# Altium schematic sheet Picc.SchDoc(Picc)
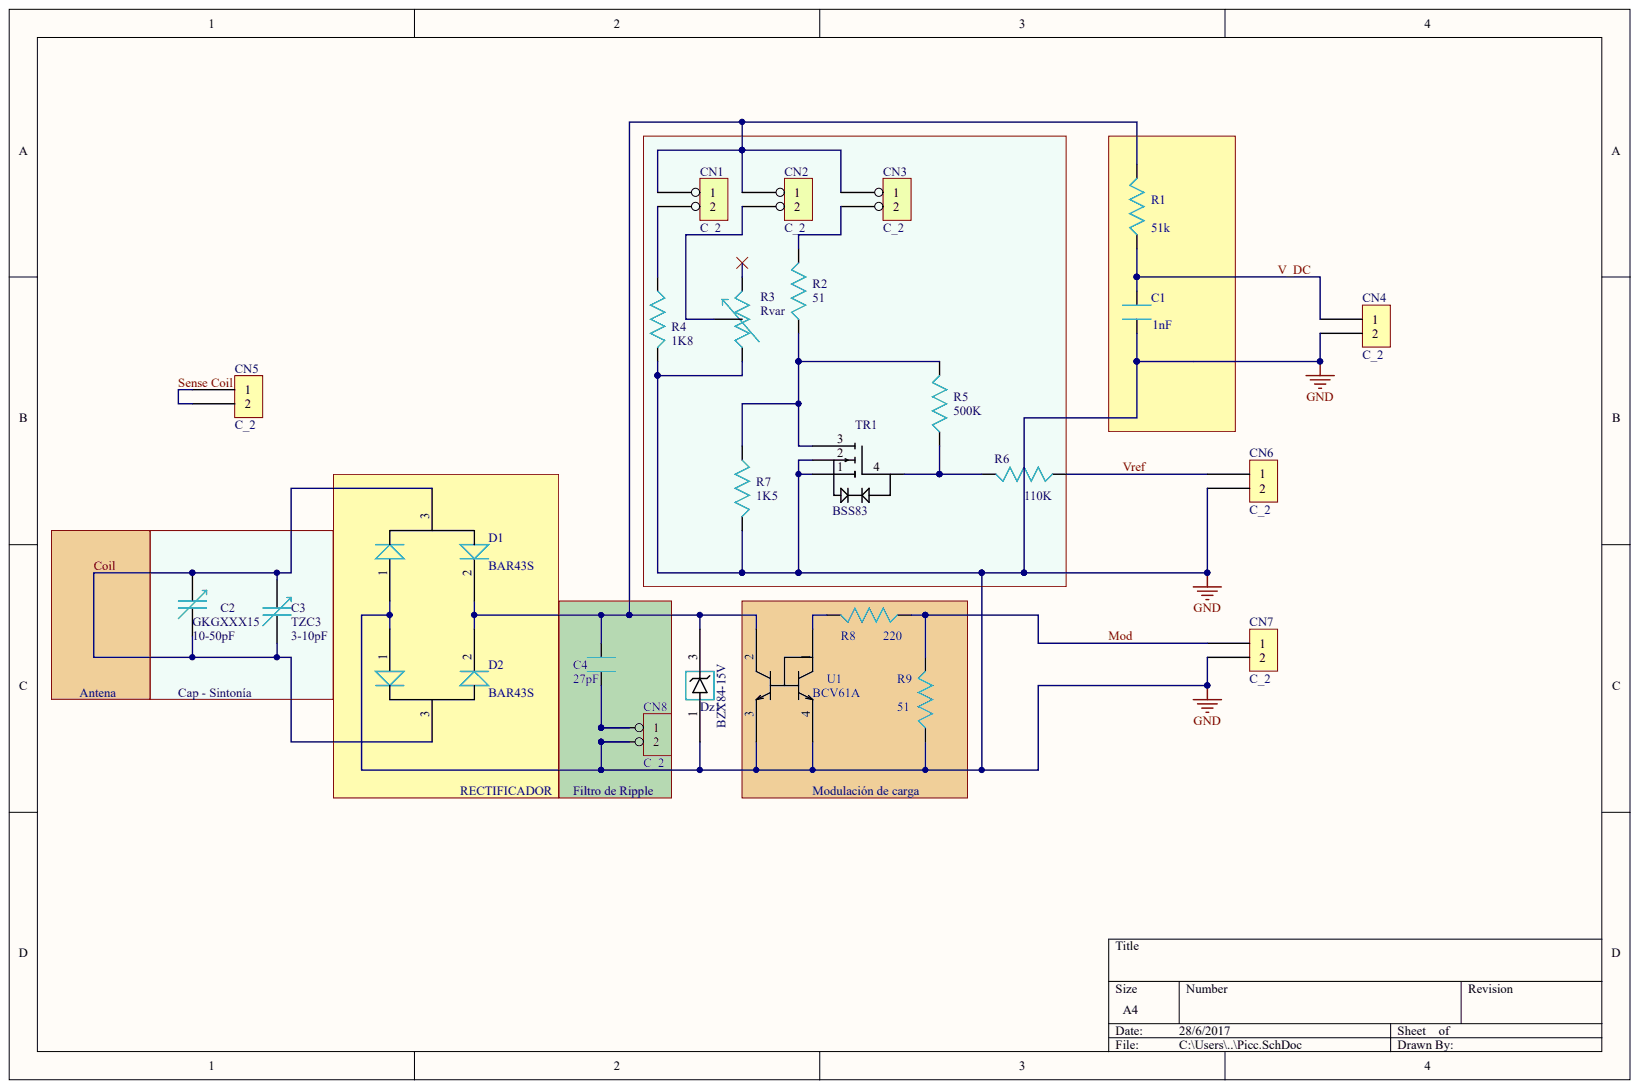
[diagram p0: Picc.SchDoc]

## Parts
C1  1nF
C2  GKGXXX15
C3  TZC3
C4  27pF
CN1  C_2
CN2  C_2
CN3  C_2
CN4  C_2
CN5  C_2
CN6  C_2
CN7  C_2
CN8  C_2
D1  BAR43S
D2  BAR43S
Dz1  BZX84-15V
R1  51k
R2  51
R3  Rvar
R4  1K8
R5  500K
R6  110K
R7  1K5
R8  220
R9  51
TR1  BSS83
U1  BCV61A

## Nets
GND: C1.1 CN4.2 CN6.2 CN7.2 CN8.2 D1.1 D2.1 Dz1.1 R3.1 R4.2 R7.2 R9.1 TR1.1 TR1.2 U1.3 U1.4
COIL: C2.0 C2.1 C3.0 C3.1 D1.3 D2.3
MOD: CN7.1 R8.1 R9.2
SENSE COIL: CN5.1 CN5.2
V_DC: C1.2 CN4.1 R1.1
VREF: CN6.1 R6.1
NetC4_1: C4.1 CN8.1
NetC4_2: C4.2 CN1.1 CN2.1 CN3.1 D1.2 D2.2 Dz1.3 R1.2 U1.2
NetCN1_2: CN1.2 R4.1
NetCN2_2: CN2.2 R3.3
NetCN3_2: CN3.2 R2.2
NetR2_1: R2.1 R5.2 R7.1 TR1.3
NetR5_1: R5.1 R6.2 TR1.4
NetR8_2: R8.2 U1.1

Not connected: R3 2

## Library data of the parts
C1-C4 CN1-CN8 D1 D2 Dz1 R1-R9 TR1 U1 = (no symbol name)
  C2: : 10-50pF
  C3: : 3-10pF
